# Altium schematic sheet CNC_shield_for_mkr1000_Sch.SchDoc
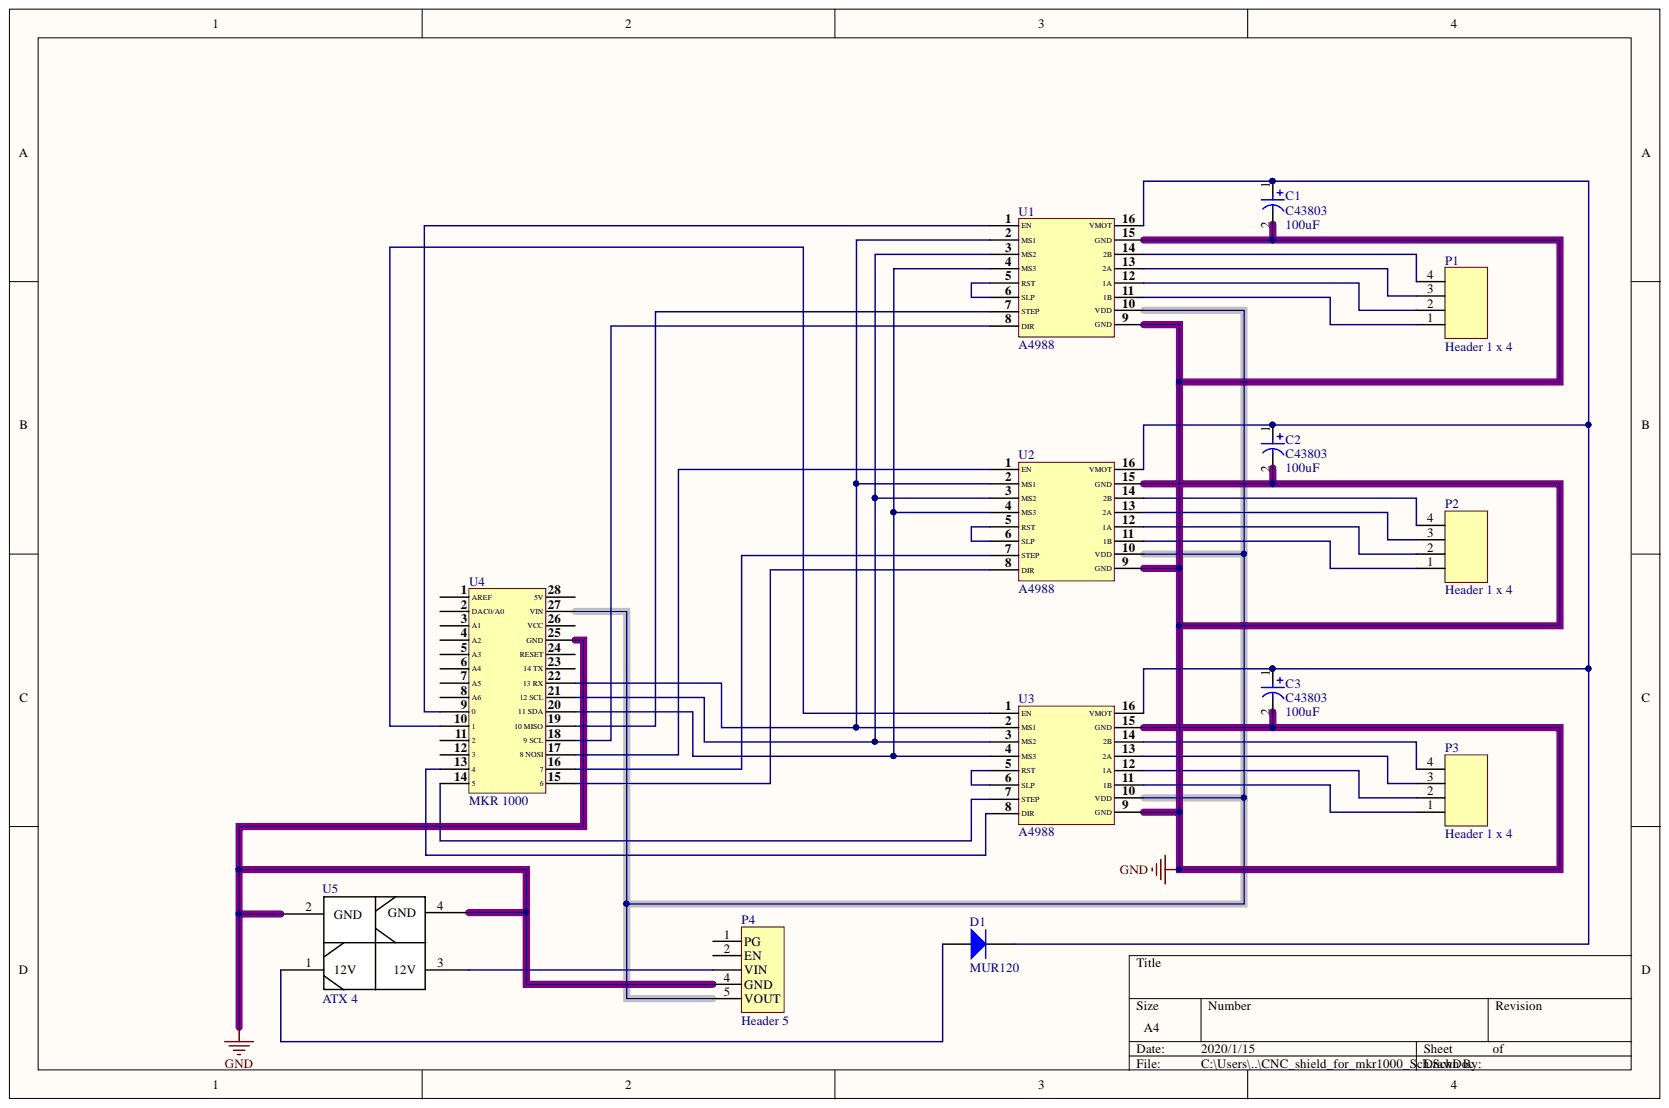
[diagram p0: CNC_shield_for_mkr1000_Sch.SchDoc]

## Parts
C1  C43803  value 100uF  [C43803]
C2  C43803  value 100uF  [C43803]
C3  C43803  value 100uF  [C43803]
D1  MUR120  [MUR120]
P1  Header 1 x 4  [HDR1X4]
P2  Header 1 x 4  [HDR1X4]
P3  Header 1 x 4  [HDR1X4]
P4  Header 5  [HDR1X5]
U1  A4988  [A4988 Driver]
U2  A4988  [A4988 Driver]
U3  A4988  [A4988 Driver]
U4  MKR 1000  [MKR1000]
U5  ATX 4  [ATX4]

## Nets
GND: C1.2 C2.2 C3.2 P4.4(GND) U1.9(GND) U1.15(GND) U2.9(GND) U2.15(GND) U3.9(GND) U3.15(GND) U4.25(GND) U5.2(GND) U5.4(GND)
NetC1_1: C1.1 C2.1 C3.1 D1.2 U1.16(VMOT) U2.16(VMOT) U3.16(VMOT)
NetD1_1: D1.1 U5.1(12V)
NetP1_1: P1.1 U1.11(1B)
NetP1_2: P1.2 U1.12(1A)
NetP1_3: P1.3 U1.13(2A)
NetP1_4: P1.4 U1.14(2B)
NetP2_1: P2.1 U2.11(1B)
NetP2_2: P2.2 U2.12(1A)
NetP2_3: P2.3 U2.13(2A)
NetP2_4: P2.4 U2.14(2B)
NetP3_1: P3.1 U3.11(1B)
NetP3_2: P3.2 U3.12(1A)
NetP3_3: P3.3 U3.13(2A)
NetP3_4: P3.4 U3.14(2B)
NetP4_3: P4.3(VIN) U5.3(12V)
NetP4_5: P4.5(VOUT) U1.10(VDD) U2.10(VDD) U3.10(VDD) U4.27(VIN)
NetU1_1: U1.1(EN) U4.9
NetU1_2: U1.2(MS1) U2.2(MS1) U3.2(MS1) U4.22(13_RX)
NetU1_3: U1.3(MS2) U2.3(MS2) U3.3(MS2) U4.21(12_SCL)
NetU1_4: U1.4(MS3) U2.4(MS3) U3.4(MS3) U4.20(11_SDA)
NetU1_5: U1.5(RST) U1.6(SLP)
NetU1_7: U1.7(STEP) U4.19(10_MISO)
NetU1_8: U1.8(DIR) U4.18(9_SCL)
NetU2_1: U2.1(EN) U4.17(8_NOSI)
NetU2_5: U2.5(RST) U2.6(SLP)
NetU2_7: U2.7(STEP) U4.16
NetU2_8: U2.8(DIR) U4.15
NetU3_1: U3.1(EN) U4.10
NetU3_5: U3.5(RST) U3.6(SLP)
NetU3_7: U3.7(STEP) U4.14
NetU3_8: U3.8(DIR) U4.13

Not connected: P4 1(PG) 2(EN); U4 1(AREF) 2(DAC0/A0) 3(A1) 4(A2) 5(A3) 6(A4) 7(A5) 8(A6) 11 12 23(14_TX) 24(RESET) 26(VCC) 28(5V)

## Library data of the parts
C1-C3 = C43803: Polarized Capacitor; Component Kind: Standard; LatestRevisionDate: 29-May-2009; LatestRevisionNote: IPC-7351 Footprint Added.; PackageDescription: Chip Capacitor; PackageReference: C0805; Published: 8-Jun-2000; Simulation: CAP; Library Name: CNC_shield_for_mkr1000_SchLib.SchLib; Publisher: Altium Limited
D1 = MUR120: Diode; Code_JEDEC: DO-214-AB; Component Kind: Standard; LatestRevisionDate: 17-Jul-2002; LatestRevisionNote: Re-released for DXP Platform.; PackageDescription: DO-214-AB/SMC; 2 C-Bend Leads; Body 7.9 x 5.9 mm, inc. leads (LxW); PackageReference: SMC; PackageVersion: Sep-1996; Published: 8-Jun-2000; Simulation: DIODE; Library Name: CNC_shield_for_mkr1000_SchLib.SchLib; Publisher: Altium Limited
P1-P3 = Header 1 x 4: Header, 4-Pin; Component Kind: Standard; LatestRevisionDate: 17-Jul-2002; LatestRevisionNote: Re-released for DXP Platform.; Library Name: CNC_shield_for_mkr1000_SchLib.SchLib; Publisher: Altium Limited
P4 = Header1x5: Header, 5-Pin; Component Kind: Standard; LatestRevisionDate: 17-Jul-2002; LatestRevisionNote: Re-released for DXP Platform.; Library Name: CNC_shield_for_mkr1000_SchLib.SchLib; Publisher: Altium Limited
U1-U3 = A4988: Motor Driver; Component Kind: Standard
U4 = Arduino MKR 1000: Arduino wifi; Component Kind: Standard
U5 = ATX 4: ATX 4Pins power connector; Component Kind: Standard; Library Name: CNC_shield_for_mkr1000_SchLib.SchLib
